# Altium schematic sheet Measuring_Thermo.SchDoc
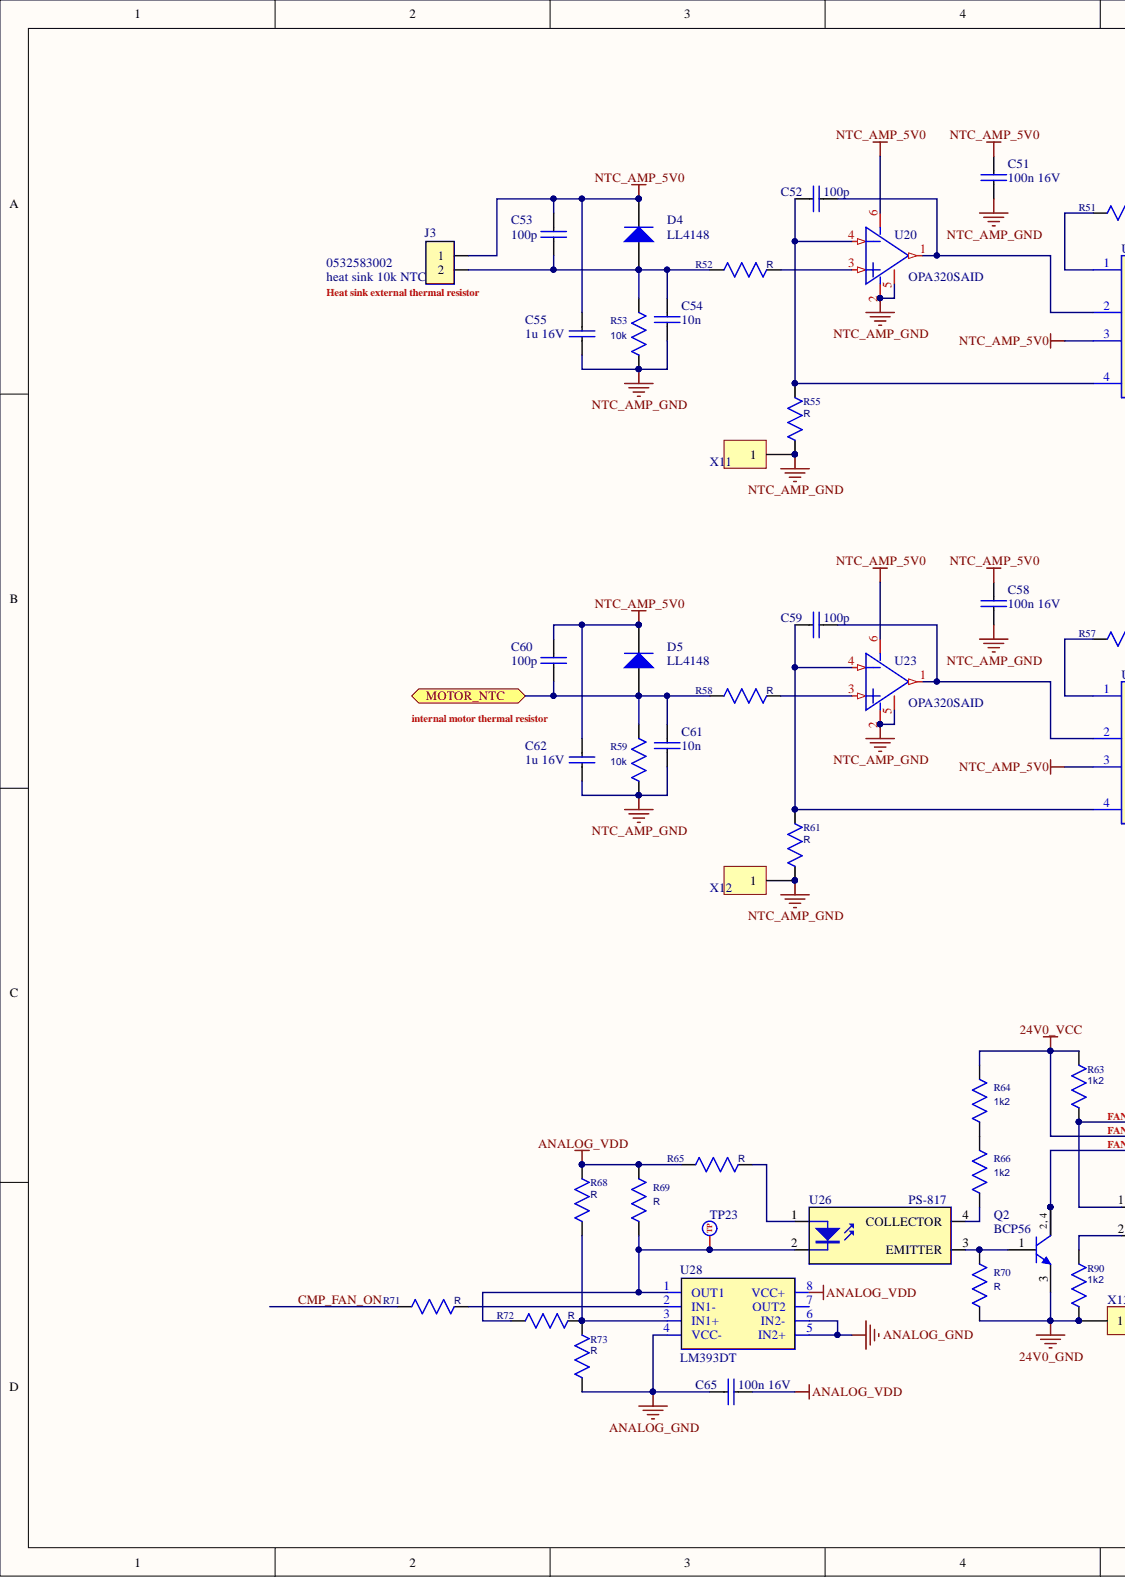
[diagram p0: Measuring_Thermo.SchDoc, left]
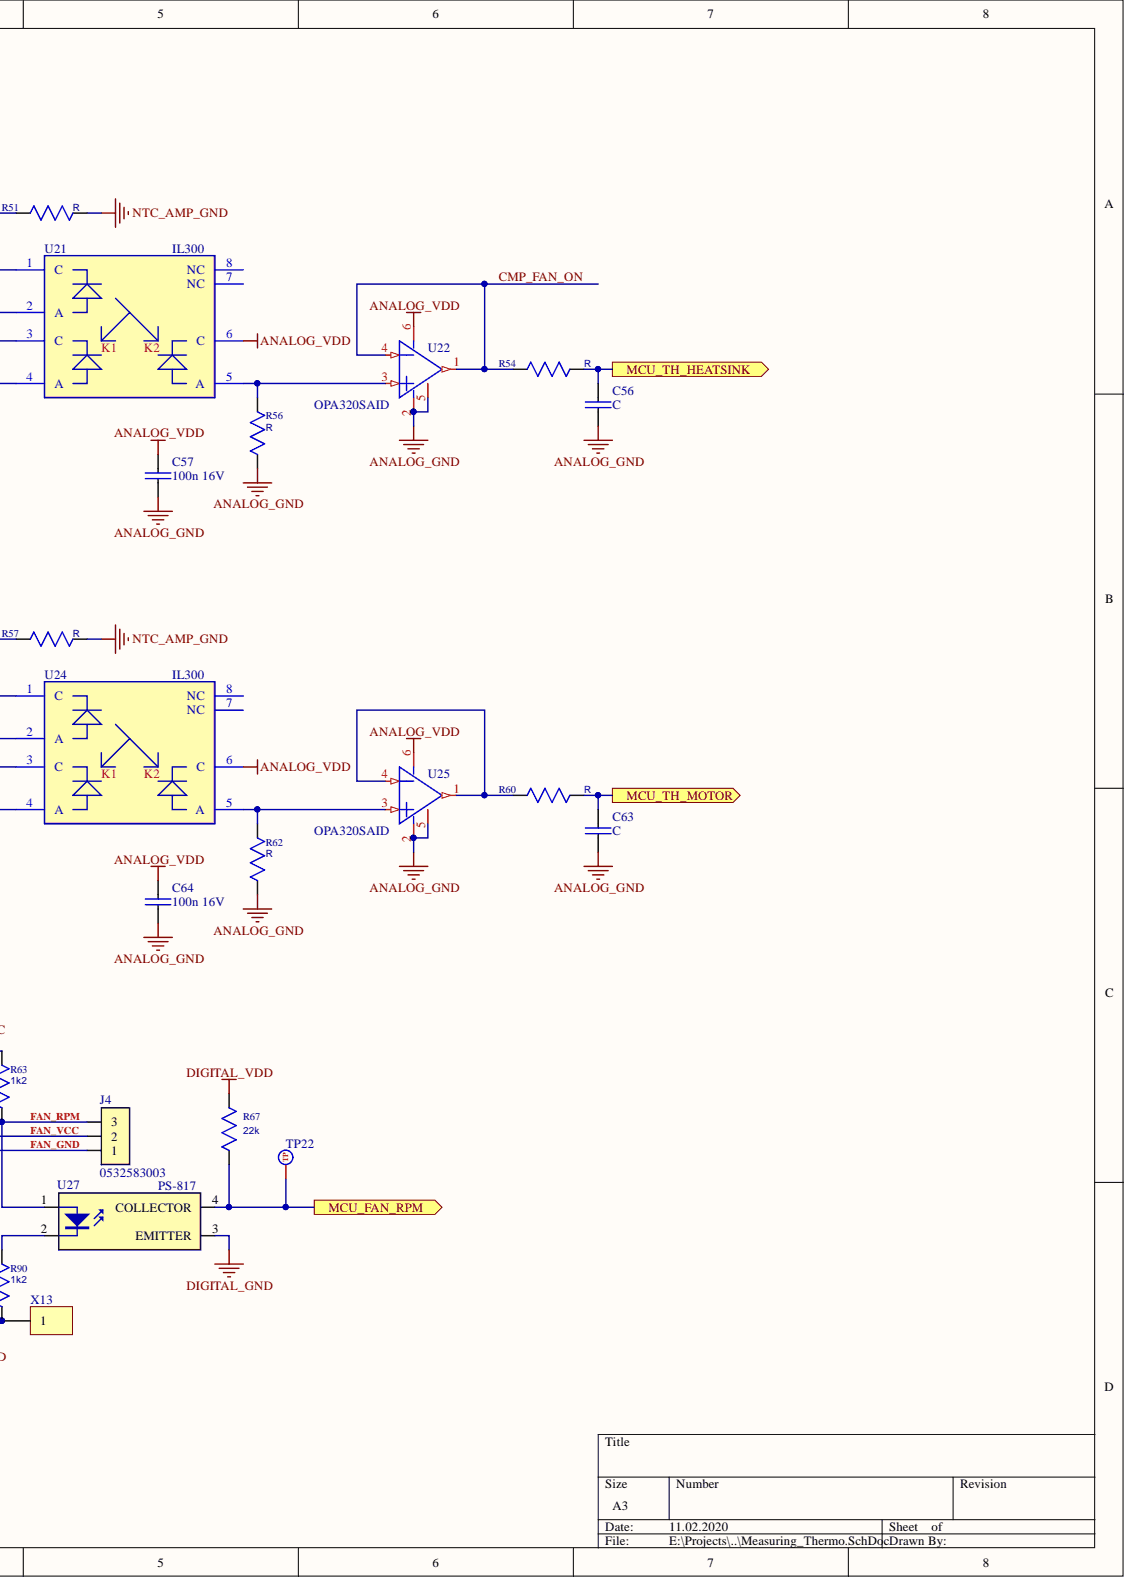
[diagram p1: Measuring_Thermo.SchDoc, right]

## Parts
C51  100n 16V  [C_0805]
C52  100p  [C_0805]
C53  100p  [C_0805]
C54  10n  [C_0805]
C55  1u 16V  [C_0805]
C56  C  [C_0805]
C57  100n 16V  [C_0805]
C58  100n 16V  [C_0805]
C59  100p  [C_0805]
C60  100p  [C_0805]
C61  10n  [C_0805]
C62  1u 16V  [C_0805]
C63  C  [C_0805]
C64  100n 16V  [C_0805]
C65  100n 16V  [C_0805]
D4  LL4148  [SOD80]
D5  LL4148  [SOD80]
J3  0532583002  [Molex 0532583002]
J4  0532583003  [Molex 0532583003]
Q2  BCP56  [SOT223]
R51  R  [R_0805]
R52  R  [R_0805]
R53  10k  [R_0805]
R54  R  [R_0805]
R55  R  [R_0805]
R56  R  [R_0805]
R57  R  [R_0805]
R58  R  [R_0805]
R59  10k  [R_0805]
R60  R  [R_0805]
R61  R  [R_0805]
R62  R  [R_0805]
R63  1k2  [R_1206]
R64  1k2  [R_0805]
R65  R  [R_0805]
R66  1k2  [R_0805]
R67  22k  [R_0805]
R68  R  [R_0805]
R69  R  [R_0805]
R70  R  [R_0805]
R71  R  [R_0805]
R72  R  [R_0805]
R73  R  [R_0805]
R90  1k2  [R_1206]
TP22  TEST_POINT  [TEST POINT D1.5]  (value not drawn)
TP23  TEST_POINT  [TEST POINT D1.5]  (value not drawn)
U20  OPA320SAID  [SOT23-6]
U21  IL300  [DIP8-300-SO]
U22  OPA320SAID  [SOT23-6]
U23  OPA320SAID  [SOT23-6]
U24  IL300  [DIP8-300-SO]
U25  OPA320SAID  [SOT23-6]
U26  PS-817  [PS817_SO]
U27  PS-817  [PS817_SO]
U28  LM393DT  [SOIC-8]
X11  PLS-1  [PLS-1-3D]  (value not drawn)
X12  PLS-1  [PLS-1-3D]  (value not drawn)
X13  PLS-1  [PLS-1-3D]  (value not drawn)

## Ports
MCU_FAN_RPM, MCU_TH_HEATSINK, MCU_TH_MOTOR, MOTOR_NTC

## Nets
24V0_GND: Q2.3 R70.1 R90.2 X13.1
24V0_VCC: J4.2 R63.1 R64.2
ANALOG_GND: C56.1 C57.1 C63.1 C64.1 C65.2 R56.1 R62.1 R73.2 U22.2 U22.5 U25.2 U25.5 U28.4(VCC-) U28.5(IN2+) U28.6(IN2-)
ANALOG_VDD: C57.2 C64.2 C65.1 R65.2 R68.1 R69.2 U21.6(C) U22.6 U24.6(C) U25.6 U28.8(VCC+)
DIGITAL_GND: U27.3(EMITTER)
DIGITAL_VDD: R67.2
NTC_AMP_5V0: C51.2 C53.1 C55.1 C58.2 C60.1 C62.1 D4.2 D5.2 J3.1 U20.6 U21.3(C) U23.6 U24.3(C)
NTC_AMP_GND: C51.1 C54.1 C55.2 C58.1 C61.1 C62.2 R51.1 R53.2 R55.1 R57.1 R59.2 R61.1 U20.2 U20.5 U23.2 U23.5 X11.1 X12.1
CMP_FAN_ON: R54.2 R71.2 U22.1 U22.4
MCU_FAN_RPM: R67.1 TP22.1 U27.4(COLLECTOR) ; port MCU_FAN_RPM
MCU_TH_HEATSINK: C56.2 R54.1 ; port MCU_TH_HEATSINK
MCU_TH_MOTOR: C63.2 R60.1 ; port MCU_TH_MOTOR
MOTOR_NTC: C60.2 C61.2 D5.1 R58.2 R59.1 ; port MOTOR_NTC
NetC52_1: C52.1 U20.1 U21.2(A)
NetC52_2: C52.2 R55.2 U20.4 U21.4(A)
NetC53_2: C53.2 C54.2 D4.1 J3.2 R52.2 R53.1
NetC59_1: C59.1 U23.1 U24.2(A)
NetC59_2: C59.2 R61.2 U23.4 U24.4(A)
NetJ4_1: J4.1 Q2.2 Q2.4
NetJ4_3: J4.3 R63.2 U27.1
NetQ2_1: Q2.1 R70.2 U26.3(EMITTER)
NetR51_2: R51.2 U21.1(C)
NetR52_1: R52.1 U20.3
NetR56_2: R56.2 U21.5(A) U22.3
NetR57_2: R57.2 U24.1(C)
NetR58_1: R58.1 U23.3
NetR60_2: R60.2 U25.1 U25.4
NetR62_2: R62.2 U24.5(A) U25.3
NetR64_1: R64.1 R66.2
NetR65_1: R65.1 U26.1
NetR66_1: R66.1 U26.4(COLLECTOR)
NetR68_2: R68.2 R72.2 R73.1 U28.3(IN1+)
NetR69_1: R69.1 R72.1 TP23.1 U26.2 U28.1(OUT1)
NetR71_1: R71.1 U28.2(IN1-)
NetR90_1: R90.1 U27.2

Not connected: U21 7(NC) 8(NC); U24 7(NC) 8(NC); U28 7(OUT2)

## Library data of the parts
C51-C65 = Cap NP: CERAMIC CAPACITOR; Component Kind: Standard; Library Name: Capacitors.SchLib
  C51 C57 C58 C64 C65: Value: 100n 16V
  C52 C53 C59 C60: Value: 100p
  C54 C61: Value: 10n
  C55 C62: Value: 1u 16V
  C56 C63: Value: C
D4 D5 = LL4148: Schottky Diode; Component Kind: Standard; Library Name: Diode.SchLib; Value: LL4148
J3 = Molex 0532583002: CONN HEADER VERT 2POS 3.5MM; Text: heat sink 10k NTC; Component Kind: Standard; Library Name: Connectors.SchLib; Value: 0532583002
J4 = Molex 0532583003: CONN HEADER VERT 3POS 3.5MM; Component Kind: Standard; Library Name: Connectors.SchLib; Value: 0532583003
Q2 = BCP56: NPN Medium Power Transistor 80V 1A; Component Kind: Standard; Library Name: Transistors.SchLib; Signal Integrity: BCP56; Value: BCP56
R51-R62 R64-R73 = R0805: Resistor; Component Kind: Standard; Library Name: Resistors.SchLib
  R51 R52 R54-R58 R60-R62 R65 R68-R73: Value: R
  R53 R59: Value: 10k
  R64 R66: Value: 1k2
  R67: Value: 22k
R63 R90 = R1206: Resistor; Component Kind: Standard; Library Name: Resistors.SchLib; Value: 1k2
TP22 TP23 = TEST_POINT: Signal Test Point; Component Kind: Standard; Library Name: Discrete.SchLib
U20 U22 U23 U25 = OPA320SAID: Precision, 20-MHz, 0.9-pA, Low-Noise, RRIO,  CMOS Operational Amplifier With Shutdown; Component Kind: Standard; Library Name: Amplifier.SchLib; Value: OPA320SAID
U21 U24 = IL300: Linear Optocoupler, High Gain Stability, Wide Bandwidth; Component Kind: Standard; Value: IL300; Library Name: Isolator.SchLib
U26 U27 = PS-817: DIP and SMD Package; Component Kind: Standard; Library Name: Isolator.SchLib
U28 = LM393DT: IC COMPARATOR LP DUAL 8-SOIC; Component Kind: Standard; Value: LM393DT; Library Name: Comporators.SchLib
X11-X13 = PLS-1: Header, 1-Pin; Component Kind: Standard; LatestRevisionDate: 17-Jul-2002; LatestRevisionNote: Re-released for DXP Platform.; Library Name: Connectors.SchLib; Publisher: Altium Limited
